# Altium schematic sheet B2B-Connectors.SchDoc(B2B-Connectors)
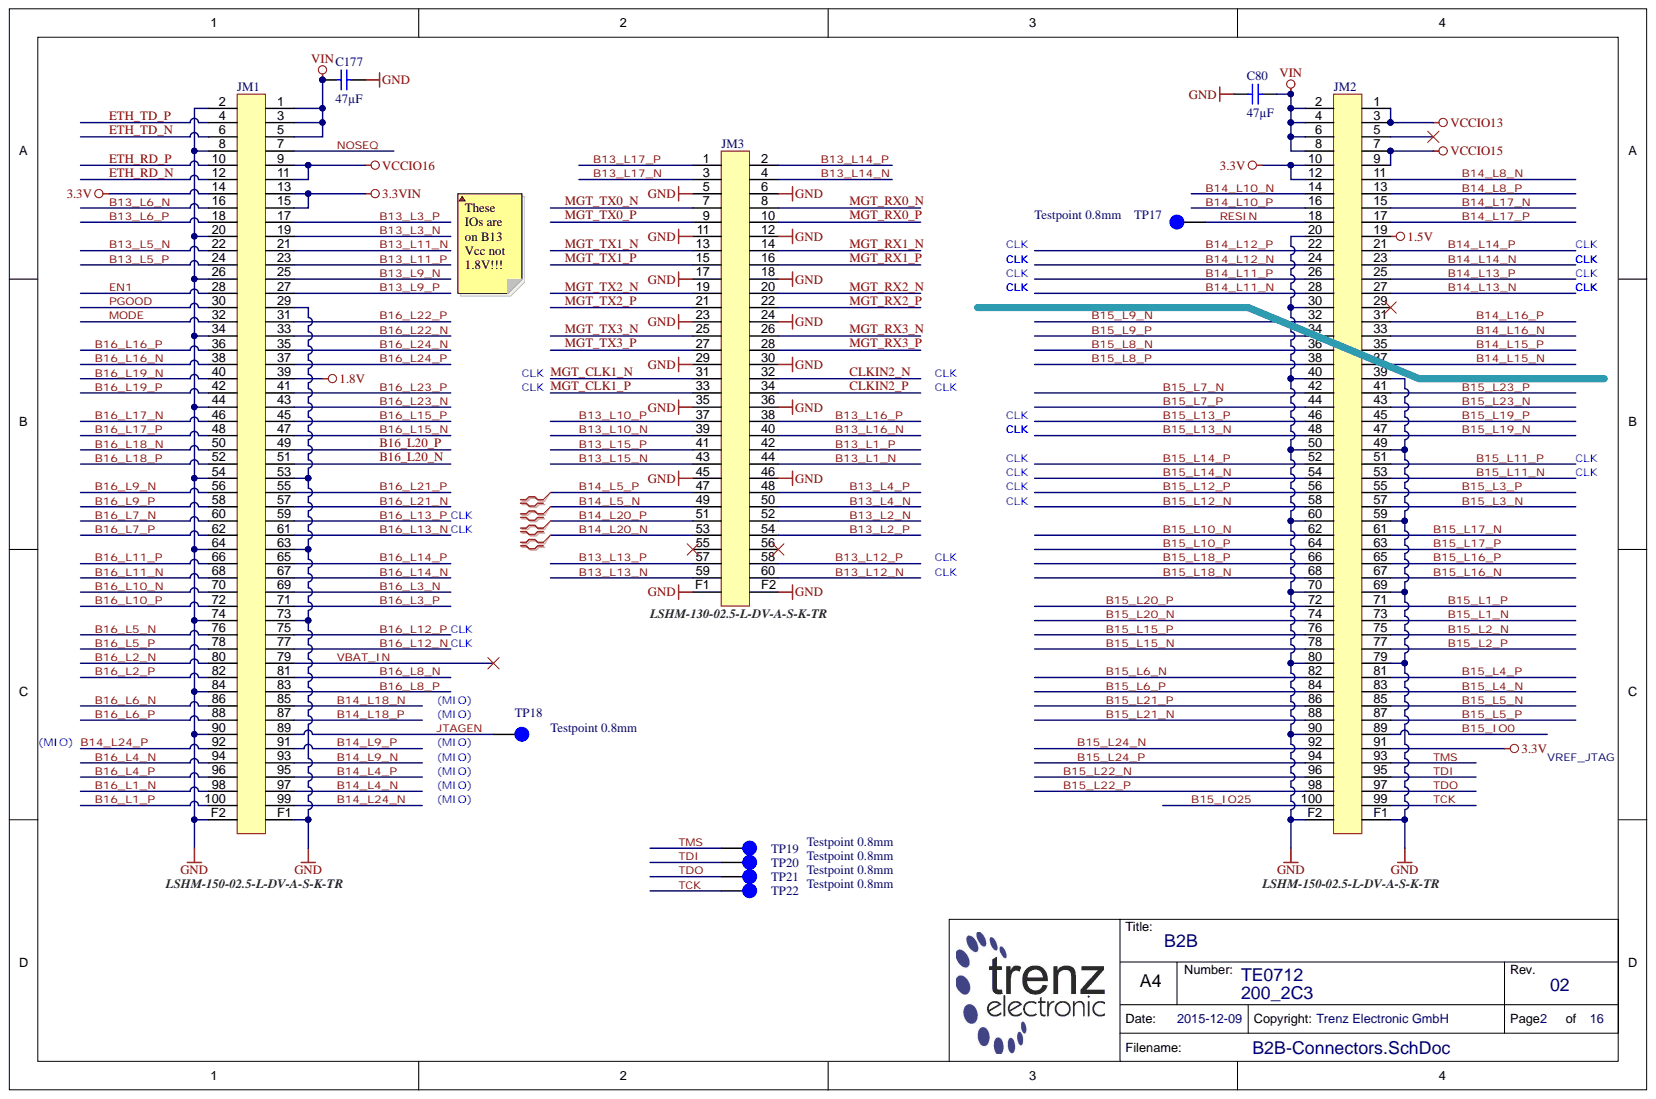
[diagram p0: B2B-Connectors.SchDoc]

## Parts
C80  47µF  [CAPC2012X135N]
C177  47µF  [CAPC2012X135N]
JM1  LSHM-150-02.5-L-DV-A-S-K-TR  [LSHM-150-XXX-X-DV-A-X]
JM1  LSHM-150-02.5-L-DV-A-S-K-TR  [LSHM-150-XXX-X-DV-A-X]
JM2  LSHM-150-02.5-L-DV-A-S-K-TR  [LSHM-150-XXX-X-DV-A-X]
JM2  LSHM-150-02.5-L-DV-A-S-K-TR  [LSHM-150-XXX-X-DV-A-X]
JM3  LSHM-130-02.5-L-DV-A-S-K-TR  [LSHM-130-04.0-x-DV-A-S-K-TR]
TP17  Testpoint 0.8mm  [TP_0.8mm]
TP18  Testpoint 0.8mm  [TP_0.8mm]
TP19  Testpoint 0.8mm  [TP_0.8mm]
TP20  Testpoint 0.8mm  [TP_0.8mm]
TP21  Testpoint 0.8mm  [TP_0.8mm]
TP22  Testpoint 0.8mm  [TP_0.8mm]

## Nets
1.5V: JM2.19
1.8V: JM1.39
3.3V: JM1.14 JM2.10 JM2.12 JM2.91
3.3VIN: JM1.13 JM1.15
GND: C80.1 C177.1 JM1.2 JM1.8 JM1.20 JM1.26 JM1.29 JM1.34 JM1.44 JM1.53 JM1.54 JM1.63 JM1.64 JM1.73 JM1.74 JM1.84 JM1.90 JM1.F1 JM1.F2 JM2.20 JM2.30 JM2.39 JM2.40 JM2.49 JM2.50 JM2.59 JM2.60 JM2.69 JM2.70 JM2.79 JM2.80 JM2.90 JM2.F1 JM2.F2 JM3.5 JM3.6 JM3.11 JM3.12 JM3.17 JM3.18 JM3.23 JM3.24 JM3.29 JM3.30 JM3.35 JM3.36 JM3.45 JM3.46 JM3.F1 JM3.F2
VCCIO13: JM2.1 JM2.3
VCCIO15: JM2.7 JM2.9
VCCIO16: JM1.9 JM1.11
VIN: C80.2 C177.2 JM1.1 JM1.3 JM1.5 JM2.2 JM2.4 JM2.6 JM2.8
B13_L1_N: JM3.44
B13_L1_P: JM3.42
B13_L2_N: JM3.52
B13_L2_P: JM3.54
B13_L3_N: JM1.19
B13_L3_P: JM1.17
B13_L4_N: JM3.50
B13_L4_P: JM3.48
B13_L5_N: JM1.22
B13_L5_P: JM1.24
B13_L6_N: JM1.16
B13_L6_P: JM1.18
B13_L9_N: JM1.25
B13_L9_P: JM1.27
B13_L10_N: JM3.39
B13_L10_P: JM3.37
B13_L11_N: JM1.21
B13_L11_P: JM1.23
B13_L12_N: JM3.60
B13_L12_P: JM3.58
B13_L13_N: JM3.59
B13_L13_P: JM3.57
B13_L14_N: JM3.4
B13_L14_P: JM3.2
B13_L15_N: JM3.43
B13_L15_P: JM3.41
B13_L16_N: JM3.40
B13_L16_P: JM3.38
B13_L17_N: JM3.3
B13_L17_P: JM3.1
B14_L4_N: JM1.97
B14_L4_P: JM1.95
B14_L5_N: JM3.49
B14_L5_P: JM3.47
B14_L8_N: JM2.11
B14_L8_P: JM2.13
B14_L9_N: JM1.93
B14_L9_P: JM1.91
B14_L10_N: JM2.14
B14_L10_P: JM2.16
B14_L11_N: JM2.28
B14_L11_P: JM2.26
B14_L12_N: JM2.24
B14_L12_P: JM2.22
B14_L13_N: JM2.27
B14_L13_P: JM2.25
B14_L14_N: JM2.23
B14_L14_P: JM2.21
B14_L15_N: JM2.37
B14_L15_P: JM2.35
B14_L16_N: JM2.33
B14_L16_P: JM2.31
B14_L17_N: JM2.15
B14_L17_P: JM2.17
B14_L18_N: JM1.85
B14_L18_P: JM1.87
B14_L20_N: JM3.53
B14_L20_P: JM3.51
B14_L24_N: JM1.99
B14_L24_P: JM1.92
B15_IO0: JM2.89
B15_IO25: JM2.100
B15_L1_N: JM2.73
B15_L1_P: JM2.71
B15_L2_N: JM2.75
B15_L2_P: JM2.77
B15_L3_N: JM2.57
B15_L3_P: JM2.55
B15_L4_N: JM2.83
B15_L4_P: JM2.81
B15_L5_N: JM2.85
B15_L5_P: JM2.87
B15_L6_N: JM2.82
B15_L6_P: JM2.84
B15_L7_N: JM2.42
B15_L7_P: JM2.44
B15_L8_N: JM2.36
B15_L8_P: JM2.38
B15_L9_N: JM2.32
B15_L9_P: JM2.34
B15_L10_N: JM2.62
B15_L10_P: JM2.64
B15_L11_N: JM2.53
B15_L11_P: JM2.51
B15_L12_N: JM2.58
B15_L12_P: JM2.56
B15_L13_N: JM2.48
B15_L13_P: JM2.46
B15_L14_N: JM2.54
B15_L14_P: JM2.52
B15_L15_N: JM2.78
B15_L15_P: JM2.76
B15_L16_N: JM2.67
B15_L16_P: JM2.65
B15_L17_N: JM2.61
B15_L17_P: JM2.63
B15_L18_N: JM2.68
B15_L18_P: JM2.66
B15_L19_N: JM2.47
B15_L19_P: JM2.45
B15_L20_N: JM2.74
B15_L20_P: JM2.72
B15_L21_N: JM2.88
B15_L21_P: JM2.86
B15_L22_N: JM2.96
B15_L22_P: JM2.98
B15_L23_N: JM2.43
B15_L23_P: JM2.41
B15_L24_N: JM2.92
B15_L24_P: JM2.94
B16_L1_N: JM1.98
B16_L1_P: JM1.100
B16_L2_N: JM1.80
B16_L2_P: JM1.82
B16_L3_N: JM1.69
B16_L3_P: JM1.71
B16_L4_N: JM1.94
B16_L4_P: JM1.96
B16_L5_N: JM1.76
B16_L5_P: JM1.78
B16_L6_N: JM1.86
B16_L6_P: JM1.88
B16_L7_N: JM1.60
B16_L7_P: JM1.62
B16_L8_N: JM1.81
B16_L8_P: JM1.83
B16_L9_N: JM1.56
B16_L9_P: JM1.58
B16_L10_N: JM1.70
B16_L10_P: JM1.72
B16_L11_N: JM1.68
B16_L11_P: JM1.66
B16_L12_N: JM1.77
B16_L12_P: JM1.75
B16_L13_N: JM1.61
B16_L13_P: JM1.59
B16_L14_N: JM1.67
B16_L14_P: JM1.65
B16_L15_N: JM1.47
B16_L15_P: JM1.45
B16_L16_N: JM1.38
B16_L16_P: JM1.36
B16_L17_N: JM1.46
B16_L17_P: JM1.48
B16_L18_N: JM1.50
B16_L18_P: JM1.52
B16_L19_N: JM1.40
B16_L19_P: JM1.42
B16_L20_N: JM1.51
B16_L20_P: JM1.49
B16_L21_N: JM1.57
B16_L21_P: JM1.55
B16_L22_N: JM1.33
B16_L22_P: JM1.31
B16_L23_N: JM1.43
B16_L23_P: JM1.41
B16_L24_N: JM1.35
B16_L24_P: JM1.37
CLKIN2_N: JM3.32
CLKIN2_P: JM3.34
EN1: JM1.28
ETH_RD_N: JM1.12
ETH_RD_P: JM1.10
ETH_TD_N: JM1.6
ETH_TD_P: JM1.4
JTAGEN: JM1.89 TP18.1
MGT_CLK1_N: JM3.31
MGT_CLK1_P: JM3.33
MGT_RX0_N: JM3.8
MGT_RX0_P: JM3.10
MGT_RX1_N: JM3.14
MGT_RX1_P: JM3.16
MGT_RX2_N: JM3.20
MGT_RX2_P: JM3.22
MGT_RX3_N: JM3.26
MGT_RX3_P: JM3.28
MGT_TX0_N: JM3.7
MGT_TX0_P: JM3.9
MGT_TX1_N: JM3.13
MGT_TX1_P: JM3.15
MGT_TX2_N: JM3.19
MGT_TX2_P: JM3.21
MGT_TX3_N: JM3.25
MGT_TX3_P: JM3.27
MODE: JM1.32
NOSEQ: JM1.7
PGOOD: JM1.30
RESIN: JM2.18 TP17.1
TCK: JM2.99 TP22.1
TDI: JM2.95 TP20.1
TDO: JM2.97 TP21.1
TMS: JM2.93 TP19.1
VBAT_IN: JM1.79

Not connected: JM2 5 29; JM3 55 56

## Library data of the parts
C80 C177 = 24718: KON 47µF 6,3V  X5R SMD 20%; Database Table Name: C_2012_0805; Mixed: SMT; Preferred: Yes; Value: 47u; Voltage: 6.3V; AN: 24718; Case: 2012 (0805); Component Kind: Standard; Library Name: TE.DbLib; Technology: X5R; Tolerance: 20%
JM1 JM2 = 23836: Samtec Razor Beam REF?189016?01, LF-02.5, 100 Pins, für alle 4x5 SoMs; Database Table Name: CON_B2B; Mixed: SMT; Trenz-Stock: Yes; AN: 23836; Component Kind: Standard; H-ART: LSHM-150-02.5-L-DV-A-S-K-TR; Library Name: TE.DbLib; HelpURL: B:\AD\adlib\datasheet\LSHM-1XX-XX-XXX-DV-A
JM1 JM2 = 23836: Samtec Razor Beam REF?189016?01, LF-02.5, 100 Pins, für alle 4x5 SoMs; Database Table Name: CON_B2B; Mixed: SMT; Preferred: Yes; AN: 23836; Component Kind: Standard; H-ART: LSHM-150-02.5-L-DV-A-S-K-TR; Library Name: TE.DbLib; Trenz-Stock: Yes; HelpURL: B:\AD\adlib\datasheet\LSHM-1XX-XX-XXX-DV-A
JM3 = 26125: Samtec Razor Beam REF?189017?01, LF-02.5, 60 Pins, für alle 4x5 SoMs; Database Table Name: CON_B2B; Mixed: SMT; Trenz-Stock: Yes; AN: 26125; Component Kind: Standard; H-ART: LSHM-130-02.5-L-DV-A-S-K-TR; Library Name: TE.DbLib; HelpURL: B:\AD\adlib\datasheet\LSHM-1XX-XX-XXX-DV-A
TP17-TP22 = 26289: Database Table Name: PCB; Mixed: 1; AN: 26289; Component Kind: Standard; Description: Testpoint 0.8mm; Library Name: TE.DbLib
